# Altium schematic sheet [04] - USB-AND-GPIOS.SchDoc("[04] - USB-AND-GPIOS")
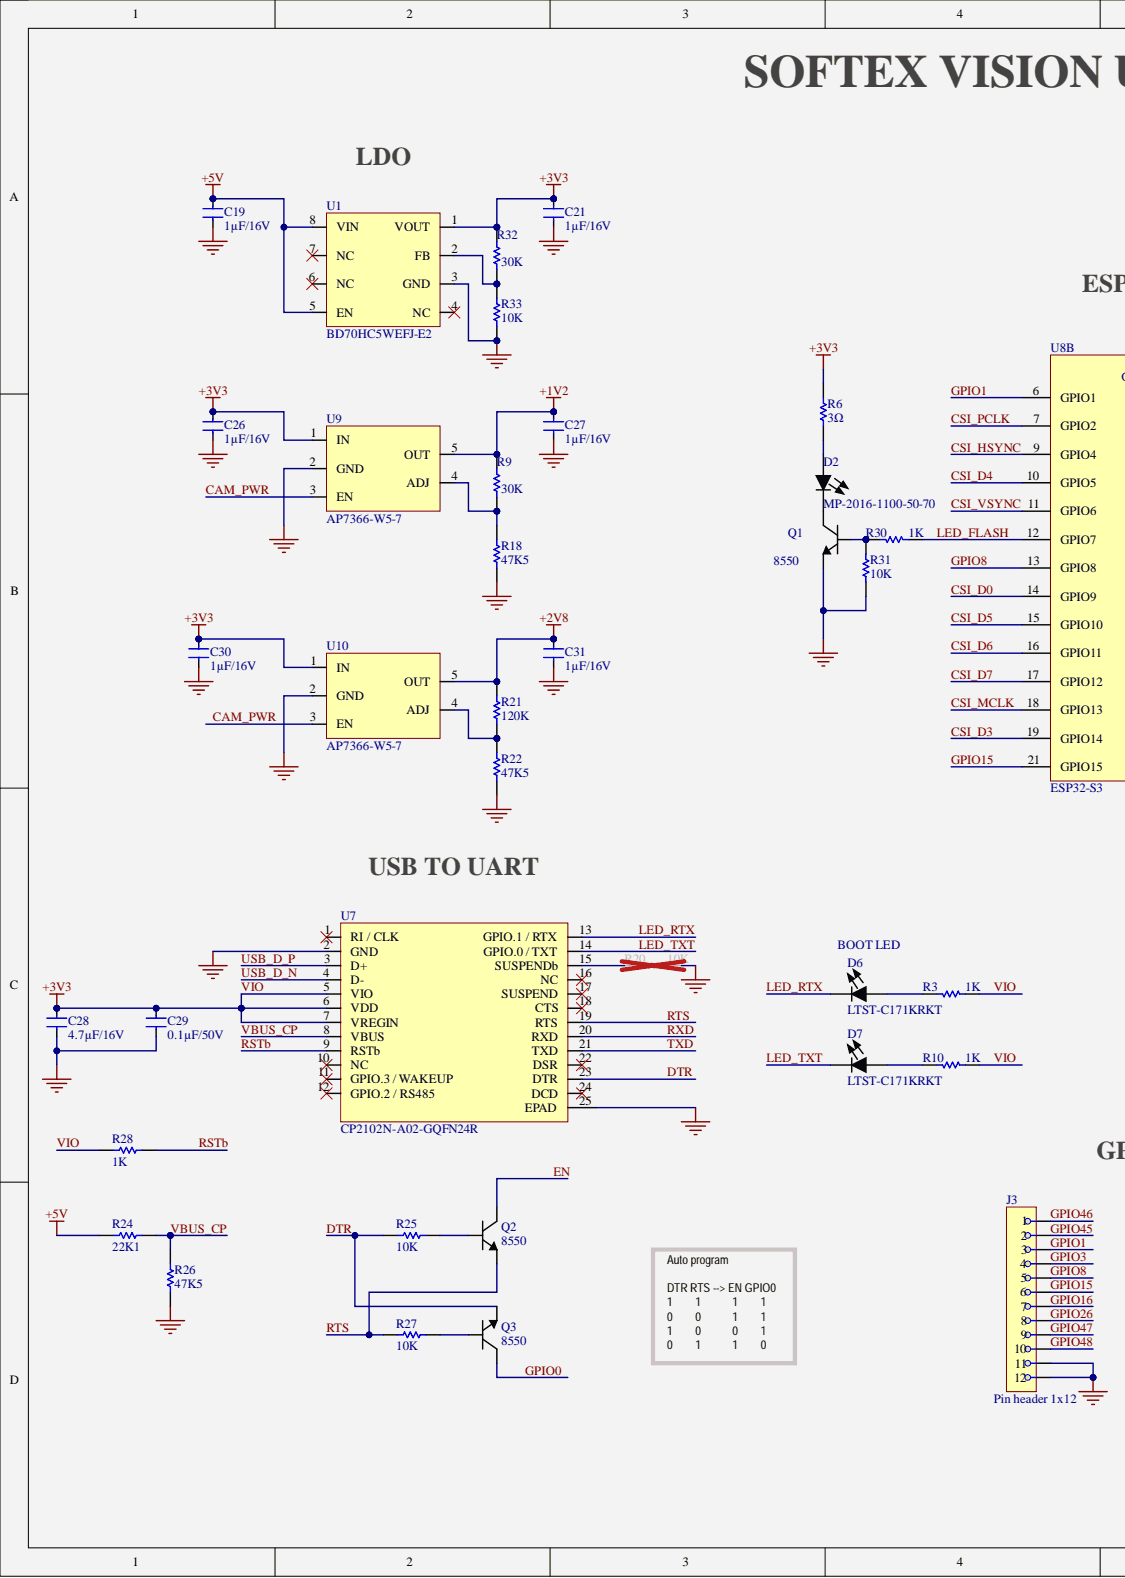
[diagram p0: [04] - USB-AND-GPIOS.SchDoc, left]
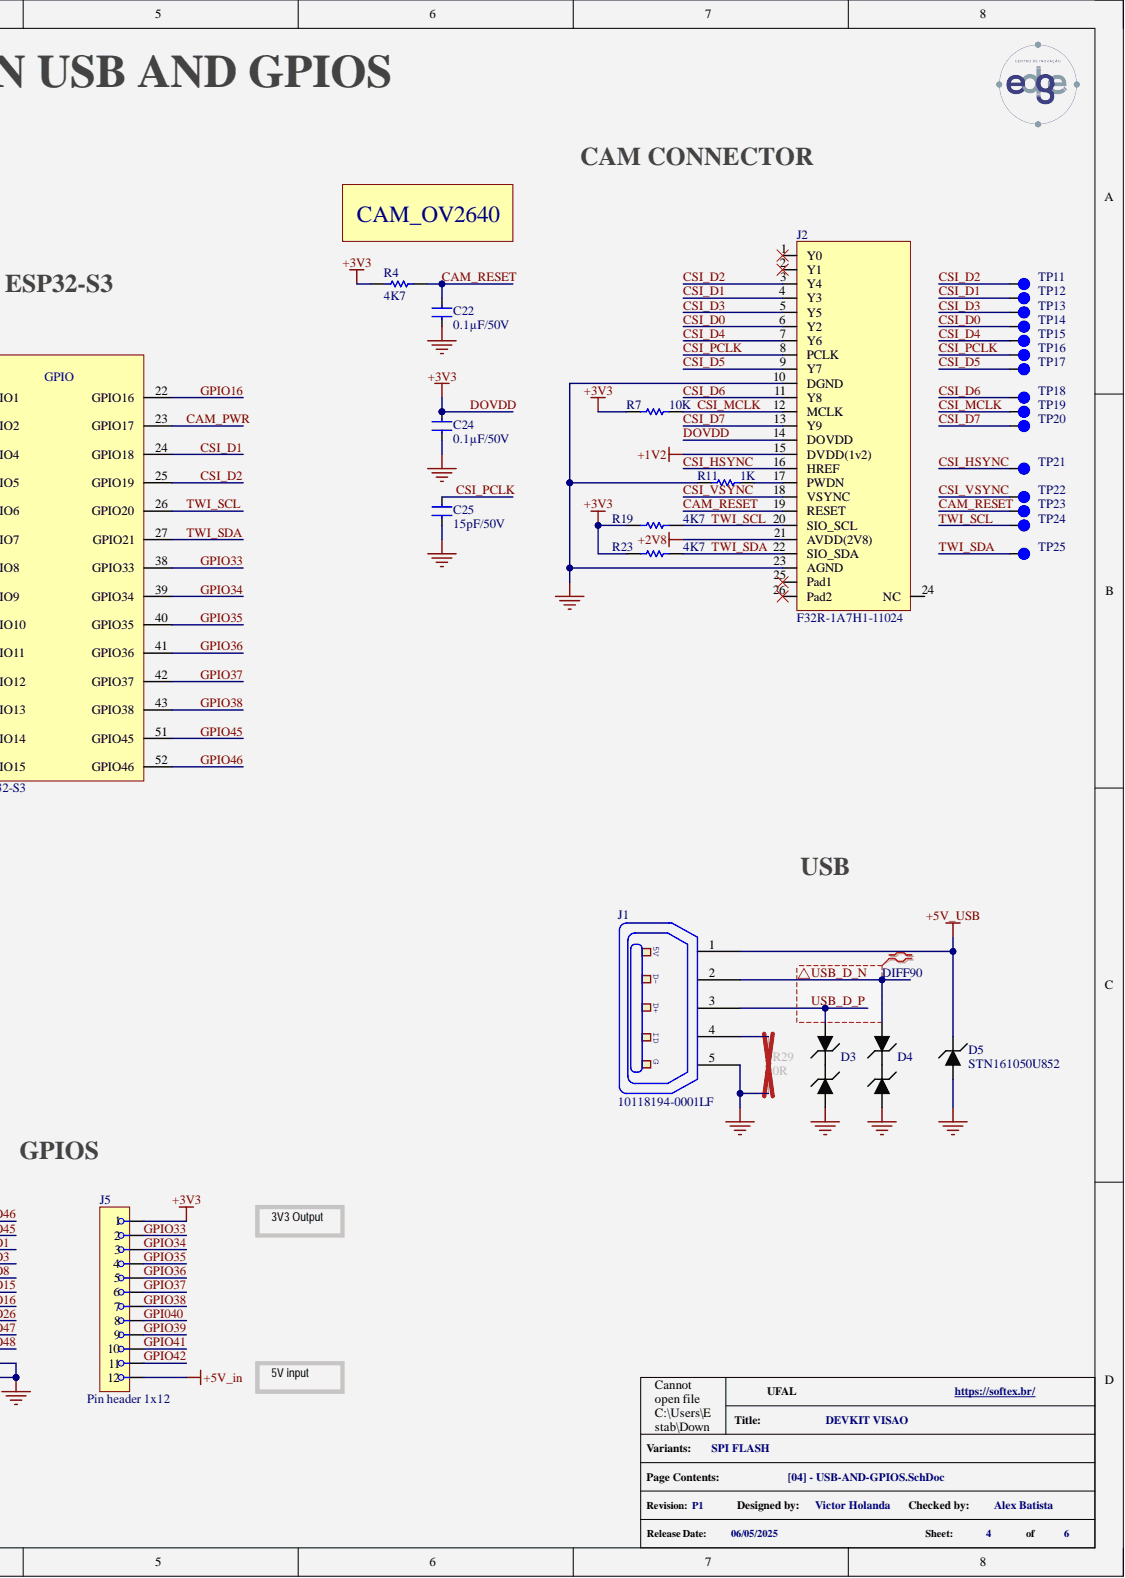
[diagram p1: [04] - USB-AND-GPIOS.SchDoc, right]

## Parts
*  CAM_OV2640  (mechanical)
C19  1µF/16V  [C0805]
C21  1µF/16V  [C0805]
C22  0.1µF/50V  [C0805]
C24  0.1µF/50V  [C0805]
C25  15pF/50V  [C0805]
C26  1µF/16V  [C0805]
C27  1µF/16V  [C0805]
C28  4.7µF/16V  [C0805]
C29  0.1µF/50V  [C0805]
C30  1µF/16V  [C0805]
C31  1µF/16V  [C0805]
D2  MP-2016-1100-50-70  [MP-2016-1100-50-70]
D3  SZESD7451N2T5G  [SZESD7451N2T5G]  (value not drawn)
D4  SZESD7451N2T5G  [SZESD7451N2T5G]  (value not drawn)
D5  STN161050U852  [STN161050U852]
D6  LTST-C171KRKT  [LTST-C171KRKT]
D7  LTST-C171KRKT  [LTST-C171KRKT]
J1  10118194-0001LF  [10118194-0001LF]
J2  F32R-1A7H1-11024  [F32R1A7H111024]
J3  Pin header 1x12  [PH1-12-UA]
J5  Pin header 1x12  [PH1-12-UA]
Q1  8550  [MMSS8050-H-TP]
Q2  8550  [MMSS8050-H-TP]
Q3  8550  [MMSS8050-H-TP]
R3  1K  [R0805]
R4  4K7  [R0805]
R6  3Ω  [R0805]
R7  10K  [R0805]
R9  30K  [R0805]
R10  1K  [R0805]
R11  1K  [R0805]
R18  47K5  [R0805]
R19  4K7  [R0805]
R20  10K  [R0805]
R21  120K  [R0805]
R22  47K5  [R0805]
R23  4K7  [R0805]
R24  22K1  [R0805]
R25  10K  [R0805]
R26  47K5  [R0805]
R27  10K  [R0805]
R28  1K  [R0805]
R29  0R  [R0805]
R30  1K  [R0805]
R31  10K  [R0805]
R32  30K  [R0805]
R33  10K  [R0805]
TP11  TestPoint  [Test Point]  (value not drawn)  (no BOM)
TP12  TestPoint  [Test Point]  (value not drawn)  (no BOM)
TP13  TestPoint  [Test Point]  (value not drawn)  (no BOM)
TP14  TestPoint  [Test Point]  (value not drawn)  (no BOM)
TP15  TestPoint  [Test Point]  (value not drawn)  (no BOM)
TP16  TestPoint  [Test Point]  (value not drawn)  (no BOM)
TP17  TestPoint  [Test Point]  (value not drawn)  (no BOM)
TP18  TestPoint  [Test Point]  (value not drawn)  (no BOM)
TP19  TestPoint  [Test Point]  (value not drawn)  (no BOM)
TP20  TestPoint  [Test Point]  (value not drawn)  (no BOM)
TP21  TestPoint  [Test Point]  (value not drawn)  (no BOM)
TP22  TestPoint  [Test Point]  (value not drawn)  (no BOM)
TP23  TestPoint  [Test Point]  (value not drawn)  (no BOM)
TP24  TestPoint  [Test Point]  (value not drawn)  (no BOM)
TP25  TestPoint  [Test Point]  (value not drawn)  (no BOM)
U1  BD70HC5WEFJ-E2  [BD70HC5WEFJ-E2]
U7  CP2102N-A02-GQFN24R  [CP2102N-A02-GQFN24]
U8  ESP32-S3  [ESP32-S3]  (part B)
U8
U9  AP7366-W5-7  [AP7366-W5-7]
U10  AP7366-W5-7  [AP7366-W5-7]

## Nets
+1V2: C27.2 J2.15(DVDD(1v2)) R9.2 U9.5(OUT)
+2V8: C31.2 J2.21(AVDD(2V8)) R21.2 U10.5(OUT)
+5V: C19.2 R24.2 U1.5(EN) U1.8(VIN)
+5V_in: J5.12
+5V_USB: D5.1 J1.1
GND: C19.1 C21.1 C22.2 C24.2 C25.1 C26.1 C27.1 C28.1 C29.1 C30.1 C31.1 D3.2 D4.2 D5.2 J1.5 J2.10(DGND) J2.23(AGND) J3.11 J3.12 Q1.2 R11.1 R18.1 R20.2 R22.1 R26.1 R29.1 R31.1 R33.1 U1.3(GND) U7.2(GND) U7.25(EPAD) U9.2(GND) U10.2(GND)
+3V3: C21.2 C24.1 C26.2 C28.2 C29.2 C30.2 J2.14(DOVDD) J5.1 R3.1 R4.1 R6.2 R7.1 R10.1 R19.1 R23.1 R28.1 R32.2 U1.1(VOUT) U7.5(VIO) U7.6(VDD) U7.7(VREGIN) U9.1(IN) U10.1(IN)
CAM_PWR: U8.23 U9.3(EN) U10.3(EN)
CAM_RESET: C22.1 J2.19(RESET) R4.2 TP23.1
CSI_D0: J2.6(Y2) TP14.1 U8.14
CSI_D1: J2.4(Y3) TP12.1 U8.24
CSI_D2: J2.3(Y4) TP11.1 U8.25
CSI_D3: J2.5(Y5) TP13.1 U8.19
CSI_D4: J2.7(Y6) TP15.1 U8.10
CSI_D5: J2.9(Y7) TP17.1 U8.15
CSI_D6: J2.11(Y8) TP18.1 U8.16
CSI_D7: J2.13(Y9) TP20.1 U8.17
CSI_HSYNC: J2.16(HREF) TP21.1 U8.9
CSI_MCLK: J2.12(MCLK) R7.2 TP19.1 U8.18
CSI_PCLK: C25.2 J2.8(PCLK) TP16.1 U8.7
CSI_VSYNC: J2.18(VSYNC) TP22.1 U8.11
DTR: Q3.2 R25.1 U7.23(DTR)
EN: Q2.3
GPI040: J5.8
GPIO0: Q3.3
GPIO1: J3.3 U8.6
GPIO3: J3.4
GPIO8: J3.5 U8.13
GPIO15: J3.6 U8.21
GPIO16: J3.7 U8.22
GPIO26: J3.8
GPIO33: J5.2 U8.38
GPIO34: J5.3 U8.39
GPIO35: J5.4 U8.40
GPIO36: J5.5 U8.41
GPIO37: J5.6 U8.42
GPIO38: J5.7 U8.43
GPIO39: J5.9
GPIO41: J5.10
GPIO42: J5.11
GPIO45: J3.2 U8.51
GPIO46: J3.1 U8.52
GPIO47: J3.9
GPIO48: J3.10
LED_FLASH: R30.2 U8.12
LED_RTX: D6.2 U7.13(GPIO.1_/_RTX)
LED_TXT: D7.2 U7.14(GPIO.0_/_TXT)
RSTb: R28.2 U7.9(RSTb)
RTS: Q2.2 R27.1 U7.19(RTS)
RXD: U7.20(RXD)
TWI_SCL: J2.20(SIO_SCL) R19.2 TP24.1 U8.26
TWI_SDA: J2.22(SIO_SDA) R23.2 TP25.1 U8.27
TXD: U7.21(TXD)
USB_D_N: D4.1 J1.2 U7.4(D-)
USB_D_P: D3.1 J1.3 U7.3(D+)
VBUS_CP: R24.1 R26.2 U7.8(VBUS)
NetD2_1: D2.1 R6.1
NetD2_2: D2.2 Q1.3
NetD6_1: D6.1 R3.2
NetD7_1: D7.1 R10.2
NetJ1_4: J1.4 R29.2
NetJ2_17: J2.17(PWDN) R11.2
NetQ1_1: Q1.1 R30.1 R31.2
NetQ2_1: Q2.1 R25.2
NetQ3_1: Q3.1 R27.2
NetR9_1: R9.1 R18.2 U9.4(ADJ)
NetR20_1: R20.1 U7.15(SUSPENDb)
NetR21_1: R21.1 R22.2 U10.4(ADJ)
NetR32_1: R32.1 R33.2 U1.2(FB)

Not connected: J2 1(Y0) 2(Y1) 24(NC) 25(Pad1) 26(Pad2); U1 4(NC) 6(NC) 7(NC); U7 1(RI_/_CLK) 10(NC) 11(GPIO.3_/_WAKEUP) 12(GPIO.2_/_RS485) 16(NC) 17(SUSPEND) 18(CTS) 22(DSR) 24(DCD)

## Library data of the parts
C19 C21 C26 C27 C30 C31 = CL21B105KOFNNNE: MLCC - 1µF 0805 16V X7R ±10%; Manufacturer 1: Samsung; .PCBChecked: Victor Holanda; Capacitance: 1uF; Case Code (Imperial): 0805; Case Code (Metric): 2012; Case/Package: 0805; Category: Ceramic Capacitors; Component Kind: Standard; ComponentLink3Description: https://datasheet.ciiva.com/25800/cl21b105kofnnne-25800257.pdf?src-supplier=Digi-Key; ComponentLink3URL: https://datasheet.ciiva.com/25800/cl21b105kofnnne-25800257.pdf?src-supplier=Digi-Key; Construction: Flat; Contact Plating: Tin; Depth: 1.25mm; Dielectric: X7R; Height: 1.25mm; Lead Free: Lead Free; Length: 2mm; Manufacturer Part Number 1: CL21B105KOFNNNE; Max Operating Temperature: 125°C; Min Operating Temperature: -55°C; Mount: Surface Mount; Package Quantity: 1; Packaging: Tape and Reel; Pricing 1: 1=0,10000, 10=0,05600, 50=0,03060, 100=0,02500, 500=0,01782, 1000=0,01401 (USD); Radiation Hardening: No; RoHS: Yes; RoHS Compliant: Yes; Series: CL; Stock 1: 1044699; Supplier 1: Digi-Key; Supplier Part Number 1: 1276-1026-1-ND; Thickness: 0.053inch; Tolerance: 10%; Voltage: 16V; Voltage Rating: 16V; Voltage Rating (DC): 16V; Width: 0.049inch; .SCHChecked: Victor Holanda; Library Name: Softex.SchLib
C22 C24 C29 = CL21B104KBCNNNC: MLCC - 0.1µF 0805 50V X7R ±10%; Manufacturer 1: Samsung; .PCBChecked: Victor Holanda; Capacitance: 100nF; Material: Ceramic; Case Code (Imperial): 0805; Case Code (Metric): 2012; Case/Package: 0805; Category: Ceramic Capacitors; Component Kind: Standard; ComponentLink3Description: https://datasheet.ciiva.com/25710/cl21b104kbcnnnc-25710182.pdf?src-supplier=Digi-Key; ComponentLink3URL: https://datasheet.ciiva.com/25710/cl21b104kbcnnnc-25710182.pdf?src-supplier=Digi-Key; Construction: Flat; Contact Plating: Tin; Depth: 1.25mm; Dielectric: X7R; Height: 0.85mm; Lead Free: Lead Free; Length: 2mm; Manufacturer Part Number 1: CL21B104KBCNNNC; Max Operating Temperature: 125°C; Min Operating Temperature: -55°C; Mount: Surface Mount; Packaging: Tape and Reel; Pricing 1: 1=0,10000, 10=0,04800, 50=0,02620, 100=0,02140, 500=0,01528, 1000=0,01201 (USD); Radiation Hardening: No; RoHS: Yes; RoHS Compliant: Yes; Series: CL; Stock 1: 3198898; Supplier 1: Digi-Key; Supplier 2: Mouser; Supplier Part Number 1: 1276-1003-1-ND; Supplier Part Number 2: 187-CL21B104KBCNNNC; Thickness: 0.037inch; Tolerance: 10%; Voltage: 50V; Voltage Rating: 50V; Voltage Rating (DC): 50V; Width: 0.049inch; .SCHChecked: Victor Holanda; Library Name: Softex.SchLib
C25 = 08055C150KAT2A: MLCC - 15pF 0805 50V X7R ±10%; Manufacturer 1: Kyocera AVX; .PCBChecked: Victor Holanda; Capacitance: 15pF; Case Code (Imperial): 0805; Case Code (Metric): 2012; Case/Package: 0805; Category: Ceramic Capacitors; Component Kind: Standard; Construction: Flat; Depth: 1.25mm; Dielectric: X7R; Length: 2.01mm; Manufacturer Part Number 1: 08055C150KAT2A; Max Operating Temperature: 125°C; Min Operating Temperature: -55°C; Mount: Surface Mount; Number of Pins: 2; Package Quantity: 4000; Packaging: Tape and Reel; Pricing 1: 1=0,23000, 10=0,15700, 50=0,09400, 100=0,07940, 500=0,05434, 1000=0,04807 (USD); Radiation Hardening: No; REACH SVHC: Unknown; RoHS: Yes; Stock 1: 16775; Supplier 1: Digi-Key; Supplier 2: Mouser; Supplier Part Number 1: 478-11902-1-ND; Supplier Part Number 2: 581-08055C150KAT2A; Termination: SMD/SMT; Thickness: 0.037inch; Tolerance: 10%; Voltage Rating: 50V; Voltage Rating (DC): 50V; Width: 0.049inch; .SCHChecked: Victor Holanda; Library Name: Softex.SchLib
C28 = CL21A475KOFNNNE: MLCC - 4.7µF 0805 16V X5R ±10%; Manufacturer 1: Samsung; .PCBChecked: Victor Holanda; Capacitance: 4.7uF; Case Code (Imperial): 0805; Case Code (Metric): 2012; Case/Package: 0805; Category: Ceramic Capacitors; Component Kind: Standard; ComponentLink3Description: https://datasheet.ciiva.com/25710/cl21a475kofnnne-25710354.pdf?src-supplier=Digi-Key; ComponentLink3URL: https://datasheet.ciiva.com/25710/cl21a475kofnnne-25710354.pdf?src-supplier=Digi-Key; ComponentLink4Description: https://datasheet.ciiva.com/16740/cl21a475kofnnne-16740646.pdf?src-supplier=Future+Electronics; ComponentLink4URL: https://datasheet.ciiva.com/16740/cl21a475kofnnne-16740646.pdf?src-supplier=Future+Electronics; ComponentLink5Description: https://datasheet.ciiva.com/26838/getdatasheetpartid-357424-26838625.pdf?src-supplier=Verical; ComponentLink5URL: https://datasheet.ciiva.com/26838/getdatasheetpartid-357424-26838625.pdf?src-supplier=Verical; Construction: Flat; Contact Plating: Tin; Depth: 1.25mm; Dielectric: X5R; Height: 1.25mm; Length: 2mm; Manufacturer Part Number 1: CL21A475KOFNNNE; Max Operating Temperature: 85°C; Min Operating Temperature: -55°C; Mount: Surface Mount; Packaging: Tape and Reel; Pricing 1: 1=0,12000, 10=0,08600, 50=0,04880, 100=0,04050, 500=0,02838, 1000=0,02350 (USD); Radiation Hardening: No; RoHS: Yes; RoHS Compliant: Yes; Series: CL; Stock 1: 605133; Supplier 1: Digi-Key; Supplier Part Number 1: 1276-1065-1-ND; Thickness: 0.053inch; Tolerance: 10%; Voltage Rating: 16V; Width: 0.049inch; .SCHChecked: Victor Holanda; Library Name: Softex.SchLib
D2 = MP-2016-1100-50-70: LED - Uni-Color White 27lm 5000K Chip LED 2-Pin SMD T/R; Manufacturer 1: Luminus Devices; .PCBChecked: Victor H; Color Rendering Index (CRI): 70; Forward Voltage: 3V; Lens Style: Rectangular; Max Current Rating: 120mA; Max Power Dissipation: 816mW; Number of LEDs: 1; Reverse Voltage: 5V; Test Current: 60mA; Thermal Resistance of Package: 35°C/W; Viewing Angle: 110°; Case Code (Imperial): 0806; Case Code (Metric): 2016; Case/Package: 0806; Category: Uncategorized; Color: White; Component Kind: Standard; ComponentLink1Description: https://datasheet.ciiva.com/48679/luminus-mp2016-datasheet-48679211.pdf?src-supplier=Avnet; ComponentLink1URL: https://datasheet.ciiva.com/48679/luminus-mp2016-datasheet-48679211.pdf?src-supplier=Avnet; Correlated Color Temperature (CCT): 5000 K; Luminous Flux: 27lm; Manufacturer Part Number 1: MP-2016-1100-50-70; Max Operating Temperature: 80°C; Min Operating Temperature: -40°C; Mount: Surface Mount; Number of Pins: 2; Packaging: Tape and Reel; Pricing 1: 1=0,16000, 10=0,08600, 100=0,04060, 500=0,03476, 1000=0,02674, 2000=0,02514 (USD); RoHS: Yes; RoHS Compliant: Yes; Stock 1: 60114; Supplier 1: Digi-Key; Supplier Part Number 1: 1214-1209-1-ND; .SCHChecked: Victor H; Library Name: softex-devkit-visao.SchLib
D3 D4 = SZESD7451N2T5G: Transient Voltage Suppressors low cap ESD protection in SOD-882; Manufacturer 1: ON Semiconductor; .PCBChecked: Victor H; Capacitance: 0.35pF; Clamping Voltage: 13V; Direction: Bidirectional; Element Configuration: Single; Min Breakdown Voltage: 6V; Number of Bidirectional Channels: 1; Power Line Protection: No; Reverse Standoff Voltage: 3.3V; Category: TVS Diodes; Component Kind: Standard; ComponentLink1Description: https://datasheet.ciiva.com/48533/esd7451-d-48533317.pdf?src-supplier=Avnet; ComponentLink1URL: https://datasheet.ciiva.com/48533/esd7451-d-48533317.pdf?src-supplier=Avnet; Lead Free: Lead Free; Manufacturer Part Number 1: SZESD7451N2T5G; Max Operating Temperature: 150°C; Min Operating Temperature: -55°C; Mount: Surface Mount; Number of Pins: 2; Packaging: Tape and Reel; Pricing 1: 1=0,49000, 10=0,42100, 100=0,31430, 500=0,24696, 1000=0,19083, 2000=0,17400 (USD); Resistor Type: Zener; RoHS: Yes; RoHS Compliant: Yes; Stock 1: 36434; Supplier 1: Digi-Key; Supplier Part Number 1: SZESD7451N2T5GOSCT-ND; Working Voltage: 3.3V; .SCHChecked: Victor H; Library Name: softex-devkit-visao.SchLib
D5 = STN161050U852: DIODE - ESD Suppressor TVS Single Uni-Dir 30KV 2-Pin SMD; Manufacturer 1: Eaton Bussmann; .PCBChecked: Victor H; Component Kind: Standard; Manufacturer Part Number 1: STN161050U852; Pricing 1: 1=0,50000, 10=0,37600, 100=0,23410, 500=0,16016, 1000=0,12320 (USD); RoHS: No; Stock 1: 8226; Supplier 1: Digi-Key; Supplier Part Number 1: 283-STN161050U852CT-ND; .SCHChecked: Victor H; Library Name: softex-devkit-visao.SchLib
D6 D7 = LTST-C171KRKT: LED - RED 2-Pin SMD 0805; Manufacturer 1: Vishay Lite-On; .PCBChecked: Jonatha O.; Dominant Wavelength: 631nm; Forward Current: 30mA; Forward Voltage: 2V; Lens Color: Colorless; Lens Style: Diffused,Colorless,Rectangular,Clear; Lens Transparency: Clear; Luminous Intensity: 54mcd; Number of Elements: 1; Power Dissipation: 75mW; Reverse Voltage: 5V; Test Current: 20mA; Viewing Angle: 130°; Wavelength: 639nm; Case Code (Imperial): 0805; Case Code (Metric): 2012; Case/Package: 0805; Color: Red; Component Kind: Standard; ComponentLink1Description: https://datasheet.ciiva.com/12403/lite-on-ltst-c171krkt-187201-12403781.pdf?src-supplier=Mouser; ComponentLink1URL: https://datasheet.ciiva.com/12403/lite-on-ltst-c171krkt-187201-12403781.pdf?src-supplier=Mouser; ComponentLink2Description: https://datasheet.ciiva.com/11775/0900766b80dc0299-11775643.pdf?src-supplier=RSComponents; ComponentLink2URL: https://datasheet.ciiva.com/11775/0900766b80dc0299-11775643.pdf?src-supplier=RSComponents; ComponentLink3Description: https://datasheet.ciiva.com/16444/lite-on20ltst-c171krkt-16444779.pdf?src-supplier=Future+Electronics; ComponentLink3URL: https://datasheet.ciiva.com/16444/lite-on20ltst-c171krkt-16444779.pdf?src-supplier=Future+Electronics; ComponentLink4Description: https://datasheet.ciiva.com/2110/s-110-ltst-c171krkt-2110066.pdf?src-supplier=Digi-Key; ComponentLink4URL: https://datasheet.ciiva.com/2110/s-110-ltst-c171krkt-2110066.pdf?src-supplier=Digi-Key; ComponentLink5Description: https://datasheet.ciiva.com/2110/s-110-ltst-c171krkt-2110067.pdf?src-supplier=Digi-Key; ComponentLink5URL: https://datasheet.ciiva.com/2110/s-110-ltst-c171krkt-2110067.pdf?src-supplier=Digi-Key; ComponentLink6Description: https://datasheet.ciiva.com/2110/s-110-ltst-c171krkt-2110068.pdf?src-supplier=Digi-Key; ComponentLink6URL: https://datasheet.ciiva.com/2110/s-110-ltst-c171krkt-2110068.pdf?src-supplier=Digi-Key; Depth: 1.25mm; Height: 0.8mm; Illumination Color: Red; Lead Free: Lead Free; Length: 2mm; Manufacturer Part Number 1: LTST-C171KRKT; Max Operating Temperature: 85°C; Min Operating Temperature: -55°C; Mount: Surface Mount; Number of LEDs: 1; Number of Pins: 2; Package Quantity: 3000; Packaging: Tape and Reel; Power Rating: 75mW; Pricing 1: 1=0.28000, 10=0.15000, 100=0.06990, 500=0.06450, 1000=0.05052 (USD); Radiation Hardening: No; RoHS: Yes; RoHS Compliant: Yes; Series: -; Stock 1: 300217; Supplier 1: Digi-Key; Supplier Part Number 1: 160-1427-1-ND; Width: 1.25mm; .SCHChecked: Jonatha O.; Library Name: softex-devkit-visao.SchLib
J1 = 10118194-0001LF: CONN - Micro USB Type B RCP 5 POS 0.65mm Solder RA SMD 5 Terminal 1 Port T/R; Manufacturer 1: Amphenol ICC; .PCBChecked: Jose Gomes; .SCHChecked: Victor H; Category: USB Connectors; Component Kind: Standard; ComponentLink1Description: http://components.arrow.com/part/dataview/0323_04107371?src-supplier=Arrow+Europe; ComponentLink1URL: http://components.arrow.com/part/dataview/0323_04107371?src-supplier=Arrow+Europe; ComponentLink2Description: http://uk.rs-online.com/webdocs/1450/0900766b814507ee.pdf?src-supplier=RSComponents; ComponentLink2URL: http://uk.rs-online.com/webdocs/1450/0900766b814507ee.pdf?src-supplier=RSComponents; ComponentLink3Description: https://cdn.amphenol-icc.com/media/wysiwyg/files/documentation/datasheet/inputoutput/io_usb_micro.pdf?src-supplier=Avnet; ComponentLink3URL: https://cdn.amphenol-icc.com/media/wysiwyg/files/documentation/datasheet/inputoutput/io_usb_micro.pdf?src-supplier=Avnet; ComponentLink4Description: https://cdn.amphenol-icc.com/media/wysiwyg/files/documentation/datasheet/inputoutput/io_usb_micro.pdf?src-supplier=Arrow+Electronics; ComponentLink4URL: https://cdn.amphenol-icc.com/media/wysiwyg/files/documentation/datasheet/inputoutput/io_usb_micro.pdf?src-supplier=Arrow+Electronics; ComponentLink5Description: https://cdn.amphenol-icc.com/media/wysiwyg/files/documentation/datasheet/inputoutput/io_usb_micro.pdf?src-supplier=Verical; ComponentLink5URL: https://cdn.amphenol-icc.com/media/wysiwyg/files/documentation/datasheet/inputoutput/io_usb_micro.pdf?src-supplier=Verical; ComponentLink6Description: http://portal.fciconnect.com/Comergent//fci/drawing/10118192.pdf?src-supplier=Avnet+Asia; ComponentLink6URL: http://portal.fciconnect.com/Comergent//fci/drawing/10118192.pdf?src-supplier=Avnet+Asia; ComponentLink7Description: https://cdn.amphenol-icc.com/media/wysiwyg/files/documentation/datasheet/inputoutput/io_usb_micro.pdf?src-supplier=Allied+Electronics; ComponentLink7URL: https://cdn.amphenol-icc.com/media/wysiwyg/files/documentation/datasheet/inputoutput/io_usb_micro.pdf?src-supplier=Allied+Electronics; ComponentLink8Description: http://www.farnell.com/datasheets/2366786.pdf?src-supplier=Newark; ComponentLink8URL: http://www.farnell.com/datasheets/2366786.pdf?src-supplier=Newark; ComponentLink9Description: https://cdn.amphenol-icc.com/media/wysiwyg/files/documentation/datasheet/inputoutput/io_usb_micro.pdf?src-supplier=Mouser; ComponentLink9URL: https://cdn.amphenol-icc.com/media/wysiwyg/files/documentation/datasheet/inputoutput/io_usb_micro.pdf?src-supplier=Mouser; ComponentLink10Description: http://www.amphenol-icc.com/media/wysiwyg/files/drawing/10118192.pdf?src-supplier=Digi-Key; ComponentLink10URL: http://www.amphenol-icc.com/media/wysiwyg/files/drawing/10118192.pdf?src-supplier=Digi-Key; ComponentLink11Description: http://www.farnell.com/cad/1935767.pdf?src-supplier=Element14; ComponentLink11URL: http://www.farnell.com/cad/1935767.pdf?src-supplier=Element14; ComponentLink12Description: http://www.farnell.com/datasheets/2366786.pdf?src-supplier=Farnell; ComponentLink12URL: http://www.farnell.com/datasheets/2366786.pdf?src-supplier=Farnell; ComponentLink13Description: https://cdn.amphenol-icc.com/media/wysiwyg/files/documentation/datasheet/inputoutput/io_usb_micro.pdf?src-supplier=TME; ComponentLink13URL: https://cdn.amphenol-icc.com/media/wysiwyg/files/documentation/datasheet/inputoutput/io_usb_micro.pdf?src-supplier=TME; ComponentLink14Description: https://cdn.amphenol-icc.com/media/wysiwyg/files/documentation/datasheet/inputoutput/io_usb_micro.pdf?src-supplier=TTI; ComponentLink14URL: https://cdn.amphenol-icc.com/media/wysiwyg/files/documentation/datasheet/inputoutput/io_usb_micro.pdf?src-supplier=TTI; ComponentLink15Description: https://cdn.amphenol-icc.com/media/wysiwyg/files/documentation/datasheet/inputoutput/io_usb_micro.pdf?src-supplier=NAC+Semi; ComponentLink15URL: https://cdn.amphenol-icc.com/media/wysiwyg/files/documentation/datasheet/inputoutput/io_usb_micro.pdf?src-supplier=NAC+Semi; ComponentLink16Description: https://cdn.amphenol-icc.com/media/wysiwyg/files/documentation/datasheet/inputoutput/io_usb_micro.pdf?src-supplier=SOS+electronic; ComponentLink16URL: https://cdn.amphenol-icc.com/media/wysiwyg/files/documentation/datasheet/inputoutput/io_usb_micro.pdf?src-supplier=SOS+electronic; ComponentLink17Description: https://cdn.amphenol-icc.com/media/wysiwyg/files/documentation/datasheet/inputoutput/io_usb_micro.pdf?src-supplier=Freelance+Electronics; ComponentLink17URL: https://cdn.amphenol-icc.com/media/wysiwyg/files/documentation/datasheet/inputoutput/io_usb_micro.pdf?src-supplier=Freelance+Electronics; ComponentLink18Description: https://cdn.amphenol-icc.com/media/wysiwyg/files/documentation/datasheet/inputoutput/io_usb_micro.pdf?src-supplier=Heilind+Electronics; ComponentLink18URL: https://cdn.amphenol-icc.com/media/wysiwyg/files/documentation/datasheet/inputoutput/io_usb_micro.pdf?src-supplier=Heilind+Electronics; ComponentLink19Description: https://datasheet.ciiva.com/3094/1050170001-io-connectors-3094416.pdf?src-supplier=Avnet; ComponentLink19URL: https://datasheet.ciiva.com/3094/1050170001-io-connectors-3094416.pdf?src-supplier=Avnet; ComponentLink20Description: https://datasheet.ciiva.com/30165/235271050170001-io-nectors-30165183.pdf?src-supplier=Onlinecomponents.com; ComponentLink20URL: https://datasheet.ciiva.com/30165/235271050170001-io-nectors-30165183.pdf?src-supplier=Onlinecomponents.com; ComponentLink21Description: https://datasheet.ciiva.com/5742/1598502-5742508.pdf?src-supplier=Farnell; ComponentLink21URL: https://datasheet.ciiva.com/5742/1598502-5742508.pdf?src-supplier=Farnell; ComponentLink22Description: https://datasheet.ciiva.com/8015/1631959-8015288.pdf?src-supplier=Element14; ComponentLink22URL: https://datasheet.ciiva.com/8015/1631959-8015288.pdf?src-supplier=Element14; ComponentLink23Description: https://datasheet.ciiva.com/22654/1688140-22654924.pdf?src-supplier=Element14; ComponentLink23URL: https://datasheet.ciiva.com/22654/1688140-22654924.pdf?src-supplier=Element14; ComponentLink24Description: https://datasheet.ciiva.com/4598/1050170001-io-connectors-152207-4598759.pdf?src-supplier=Mouser; ComponentLink24URL: https://datasheet.ciiva.com/4598/1050170001-io-connectors-152207-4598759.pdf?src-supplier=Mouser; ComponentLink25Description: https://datasheet.ciiva.com/851/1050170001-sd-851276.pdf?src-supplier=Digi-Key; ComponentLink25URL: https://datasheet.ciiva.com/851/1050170001-sd-851276.pdf?src-supplier=Digi-Key; ComponentLink26Description: https://datasheet.ciiva.com/16668/1050170001-io-connectors-16668598.pdf?src-supplier=Avnet+Europe; ComponentLink26URL: https://datasheet.ciiva.com/16668/1050170001-io-connectors-16668598.pdf?src-supplier=Avnet+Europe; ComponentLink27Description: https://datasheet.ciiva.com/851/1050170001-sd-851277.pdf?src-supplier=Digi-Key; ComponentLink27URL: https://datasheet.ciiva.com/851/1050170001-sd-851277.pdf?src-supplier=Digi-Key; ComponentLink28Description: https://datasheet.ciiva.com/851/1050170001-sd-851278.pdf?src-supplier=Digi-Key; ComponentLink28URL: https://datasheet.ciiva.com/851/1050170001-sd-851278.pdf?src-supplier=Digi-Key; ComponentLink29Description: http://components.arrow.com/part/dataview/0323_04107373?src-supplier=Arrow+Europe; ComponentLink29URL: http://components.arrow.com/part/dataview/0323_04107373?src-supplier=Arrow+Europe; ComponentLink30Description: https://cdn.amphenol-icc.com/media/wysiwyg/files/drawing/10118194.pdf?src-supplier=Avnet; ComponentLink30URL: https://cdn.amphenol-icc.com/media/wysiwyg/files/drawing/10118194.pdf?src-supplier=Avnet; ComponentLink31Description: https://cdn.amphenol-icc.com/media/wysiwyg/files/drawing/10118194.pdf?src-supplier=Mouser; ComponentLink31URL: https://cdn.amphenol-icc.com/media/wysiwyg/files/drawing/10118194.pdf?src-supplier=Mouser; ComponentLink32Description: http://www.amphenol-icc.com/media/wysiwyg/files/drawing/10118194.pdf?src-supplier=Digi-Key; ComponentLink32URL: http://www.amphenol-icc.com/media/wysiwyg/files/drawing/10118194.pdf?src-supplier=Digi-Key; ComponentLink33Description: http://www.farnell.com/cad/2354948.pdf?src-supplier=Farnell; ComponentLink33URL: http://www.farnell.com/cad/2354948.pdf?src-supplier=Farnell; ComponentLink34Description: https://cdn.amphenol-icc.com/media/wysiwyg/files/drawing/10118194.pdf?src-supplier=RSComponents; ComponentLink34URL: https://cdn.amphenol-icc.com/media/wysiwyg/files/drawing/10118194.pdf?src-supplier=RSComponents; ComponentLink35Description: https://cdn.amphenol-icc.com/media/wysiwyg/files/drawing/10118194.pdf?src-supplier=Allied+Electronics; ComponentLink35URL: https://cdn.amphenol-icc.com/media/wysiwyg/files/drawing/10118194.pdf?src-supplier=Allied+Electronics; ComponentLink36Description: https://cdn.amphenol-icc.com/media/wysiwyg/files/drawing/10118194.pdf?src-supplier=Verical; ComponentLink36URL: https://cdn.amphenol-icc.com/media/wysiwyg/files/drawing/10118194.pdf?src-supplier=Verical; ComponentLink37Description: https://cdn.amphenol-icc.com/media/wysiwyg/files/drawing/10118194.pdf?src-supplier=Arrow+Electronics; ComponentLink37URL: https://cdn.amphenol-icc.com/media/wysiwyg/files/drawing/10118194.pdf?src-supplier=Arrow+Electronics; ComponentLink38Description: https://cdn.amphenol-icc.com/media/wysiwyg/files/drawing/10118194.pdf?src-supplier=TTI; ComponentLink38URL: https://cdn.amphenol-icc.com/media/wysiwyg/files/drawing/10118194.pdf?src-supplier=TTI; ComponentLink39Description: https://cdn.amphenol-icc.com/media/wysiwyg/files/drawing/10118194.pdf?src-supplier=NAC+Semi; ComponentLink39URL: https://cdn.amphenol-icc.com/media/wysiwyg/files/drawing/10118194.pdf?src-supplier=NAC+Semi; ComponentLink40Description: https://cdn.amphenol-icc.com/media/wysiwyg/files/drawing/10118194.pdf?src-supplier=SOS+electronic; ComponentLink40URL: https://cdn.amphenol-icc.com/media/wysiwyg/files/drawing/10118194.pdf?src-supplier=SOS+electronic; ComponentLink41Description: https://cdn.amphenol-icc.com/media/wysiwyg/files/drawing/10118194.pdf?src-supplier=Quest; ComponentLink41URL: https://cdn.amphenol-icc.com/media/wysiwyg/files/drawing/10118194.pdf?src-supplier=Quest; ComponentLink42Description: https://cdn.amphenol-icc.com/media/wysiwyg/files/drawing/10118194.pdf?src-supplier=Heilind+Electronics; ComponentLink42URL: https://cdn.amphenol-icc.com/media/wysiwyg/files/drawing/10118194.pdf?src-supplier=Heilind+Electronics; ComponentLink43Description: https://cdn.amphenol-icc.com/media/wysiwyg/files/drawing/10118194.pdf?src-supplier=TME; ComponentLink43URL: https://cdn.amphenol-icc.com/media/wysiwyg/files/drawing/10118194.pdf?src-supplier=TME; ComponentLink44Description: http://www.farnell.com/cad/2354948.pdf?src-supplier=Element14; ComponentLink44URL: http://www.farnell.com/cad/2354948.pdf?src-supplier=Element14; Manufacturer Part Number 1: 10118194-0001LF; Pricing 1: 1=0,480000, 10=0,420000, 25=0,384400, 50=0,361600, 100=0,346600, 250=0,316400, 500=0,301360 (USD); RoHS: Yes; RoHS Compliant: Yes; Stock 1: 169666; Supplier 1: Digi-Key; Supplier 2: Mouser; Supplier Part Number 1: 609-4618-1-ND; Supplier Part Number 2: 649-10118194-0001LF; Library Name: softex-devkit-visao.SchLib
J2 = F32R-1A7H1-11024: CONN - FPC/FFC Connector Socket 24 Position 0.5mm Bottom Contact Solder Right Angle Surface Mount T/R; Manufacturer 1: Amphenol ICC / FCI; .PCBChecked: Victor H; Category: FFC / FPC; Component Kind: Standard; Manufacturer Part Number 1: F32R-1A7H1-11024; Pricing 1: 1=0,82000, 10=0,64500, 50=0,64500, 100=0,56100, 500=0,50500, 1000=0,38300, 2500=0,36400, 10000=0,36400 (USD); RoHS: No; Stock 1: 588; Supplier 1: Mouser; Supplier Part Number 1: 649-F32R-1A7H1-11024; .SCHChecked: Victor H; Library Name: softex-devkit-visao.SchLib
J3 J5 = PH1-12-UA: CONN - HEADER VERT 12POS 2.54MM; Manufacturer 1: Adam Equipment; .PCBChecked: Victor H; Category: Headers and Wire Housings; Component Kind: Standard; ComponentLink1Description: http://www.adam-tech.com/catalog/2012/page_312-315.pdf?src-supplier=Future+Electronics; ComponentLink1URL: http://www.adam-tech.com/catalog/2012/page_312-315.pdf?src-supplier=Future+Electronics; ComponentLink2Description: https://datasheet.ciiva.com/16480/page-312-315-16480233.pdf?src-supplier=Future+Electronics; ComponentLink2URL: https://datasheet.ciiva.com/16480/page-312-315-16480233.pdf?src-supplier=Future+Electronics; Lead Free: Lead Free; Manufacturer Part Number 1: PH1-12-UA; Pricing 1: 1=0,21000, 10=0,17600, 100=0,13510, 500=0,11434, 1000=0,09356, 5000=0,08316, 10000=0,07536 (USD); RoHS: Yes; Stock 1: 4680; Supplier 1: Digi-Key; Supplier Part Number 1: 2057-PH1-12-UA-ND; .SCHChecked: Victor H; Library Name: softex-devkit-visao.SchLib
Q1-Q3 = MMSS8050-H-TP: TRANSISTOR - NPN 25V 1.5A SOT23; Manufacturer 1: MCC; .PCBChecked: Victor H; Collector Base Voltage (VCBO): 40V; Collector Emitter Saturation Voltage: 500mV; Element Configuration: Single; Emitter Base Voltage (VEBO): 5V; Gain Bandwidth Product: 100MHz; hFE Min: 120; Max Power Dissipation: 625mW; mouting: SMD; Case/Package: SOT-23; Category: BJTs; Collector Emitter Breakdown Voltage: 25V; Collector Emitter Voltage (VCEO): 500mV; Collector-emitter Voltage-Max: 500mV; Component Kind: Standard; ComponentLink1Description: https://datasheet.ciiva.com/18648/dss5320t-18648043.pdf?src-supplier=Digi-Key; ComponentLink1URL: https://datasheet.ciiva.com/18648/dss5320t-18648043.pdf?src-supplier=Digi-Key; ComponentLink2Description: https://datasheet.ciiva.com/11206/dss5320t-11206349.pdf?src-supplier=Avnet; ComponentLink2URL: https://datasheet.ciiva.com/11206/dss5320t-11206349.pdf?src-supplier=Avnet; ComponentLink3Description: https://datasheet.ciiva.com/16291/mmss8050-255048-16291447.pdf?src-supplier=Mouser; ComponentLink3URL: https://datasheet.ciiva.com/16291/mmss8050-255048-16291447.pdf?src-supplier=Mouser; ComponentLink4Description: https://datasheet.ciiva.com/972/mmss8050sot-23-972894.pdf?src-supplier=Digi-Key; ComponentLink4URL: https://datasheet.ciiva.com/972/mmss8050sot-23-972894.pdf?src-supplier=Digi-Key; ComponentLink5Description: https://datasheet.ciiva.com/972/mmss8050sot-23-972895.pdf?src-supplier=Digi-Key; ComponentLink5URL: https://datasheet.ciiva.com/972/mmss8050sot-23-972895.pdf?src-supplier=Digi-Key; ComponentLink6Description: https://datasheet.ciiva.com/972/mmss8050sot-23-972893.pdf?src-supplier=Digi-Key; ComponentLink6URL: https://datasheet.ciiva.com/972/mmss8050sot-23-972893.pdf?src-supplier=Digi-Key; Lead Free: Lead Free; Manufacturer Part Number 1: MMSS8050-H-TP; Max Breakdown Voltage: 25V; Max Collector Current: 1.5A; Max Operating Temperature: 150°C; Min Operating Temperature: -55°C; Mount: Surface Mount; Number of Pins: 3; Package Quantity: 3000; Packaging: Tape and Reel; Polarity: NPN; Pricing 1: 1=0,21000, 10=0,17100, 100=0,09060, 500=0,05962, 1000=0,04054 (USD); RoHS: Yes; RoHS Compliant: Yes; Series: -; Stock 1: 87310; Supplier 1: Digi-Key; Supplier Part Number 1: MMSS8050-H-TPMSCT-ND; Transition Frequency: 100MHz; .SCHChecked: Victor H; Library Name: softex-devkit-visao.SchLib
R3 R10 R11 R28 R30 = RNCP0805FTD1K00: RES - 1K 1/4W 0805 ±1%; Manufacturer 1: Stackpole Electronics; .PCBChecked: Victor Holanda; Max Power Dissipation: 250mW; Resistance: 1kR; Temperature Coefficient: 100ppm/°C; Case Code (Imperial): 0805; Case Code (Metric): 2012; Case/Package: 0805; Category: Chip SMD Resistors; Component Kind: Standard; ComponentLink7Description: http://www.seielect.com/Catalog/SEI-rncp.pdf?src-supplier=Future+Electronics; ComponentLink7URL: http://www.seielect.com/Catalog/SEI-rncp.pdf?src-supplier=Future+Electronics; ComponentLink8Description: https://www.seielect.com/Catalog/SEI-rncp.pdf?src-supplier=Digi-Key; ComponentLink8URL: https://www.seielect.com/Catalog/SEI-rncp.pdf?src-supplier=Digi-Key; ComponentLink9Description: https://www.seielect.com/catalog/SEI-Packaging.pdf?src-supplier=Digi-Key; ComponentLink9URL: https://www.seielect.com/catalog/SEI-Packaging.pdf?src-supplier=Digi-Key; Features: Moisture Resistant,Anti-Sulfur; Height: 0.024inch; Lead Free: Lead Free; Length: 0.079inch; Manufacturer Part Number 1: RNCP0805FTD1K00; Max Operating Temperature: 155°C; Min Operating Temperature: -55°C; Mount: Surface Mount; Number of Terminations: 2; Packaging: Tape and Reel; Power Rating: 250mW; Pricing 1: 1=0,10000, 10=0,07300, 100=0,02970, 1000=0,01336, 2500=0,01159 (USD); Resistor Type: Thin Film; RoHS: Yes; RoHS Compliant: Yes; Series: RNCP; Size Code: 0805; Stock 1: 333101; Supplier 1: Digi-Key; Supplier Part Number 1: RNCP0805FTD1K00CT-ND; Tolerance: 1%; Width: 0.049inch; .SCHChecked: Victor Holanda; Library Name: Softex.SchLib
R4 R19 R23 = ESR10EZPJ472: RES - 4K7 0.4W 0805 ±5%; Manufacturer 1: Rohm; .PCBChecked: Victor Holanda; Max Power Dissipation: 400mW; Resistance: 4.7kR; Temperature Coefficient: 200ppm/°C; Case Code (Imperial): 0805; Case Code (Metric): 2012; Case/Package: 0805; Category: Chip SMD Resistors; Component Kind: Standard; ComponentLink7Description: https://datasheet.ciiva.com/16212/esr10ezpd-16212069.pdf?src-supplier=Future+Electronics; ComponentLink7URL: https://datasheet.ciiva.com/16212/esr10ezpd-16212069.pdf?src-supplier=Future+Electronics; Features: Automotive AEC-Q200; Lead Free: Lead Free; Manufacturer Part Number 1: ESR10EZPJ472; Number of Terminations: 2; Packaging: Tape and Reel; Power Rating: 400mW; Pricing 1: 1=0,10000, 10=0,09000, 100=0,03450, 1000=0,01518, 2500=0,01311 (USD); RoHS: Yes; RoHS Compliant: Yes; Series: ESR; Size Code: 0805; Stock 1: 374197; Supplier 1: Digi-Key; Supplier Part Number 1: RHM4.7KKCT-ND; Tolerance: 5%; .SCHChecked: Victor Holanda; Library Name: Softex.SchLib
R6 = ERJ-6DQJ3R0V: RES - 3Ω 1/2W 0805 ±5%; Manufacturer 1: Panasonic; .PCBChecked: Victor H; Max Power Dissipation: 500mW; Resistance: 3R; Temperature Coefficient: 100ppm/°C; Case Code (Imperial): 0805; Case Code (Metric): 2012; Case/Package: 0805; Category: Chip SMD Resistors; Component Kind: Standard; ComponentLink1Description: https://industrial.panasonic.com/cdbs/www-data/pdf/RDN0000/AOA0000C313.pdf?src-supplier=Digi-Key; ComponentLink1URL: https://industrial.panasonic.com/cdbs/www-data/pdf/RDN0000/AOA0000C313.pdf?src-supplier=Digi-Key; Features: Automotive AEC-Q200; Height: 0.028inch; Length: 0.079inch; Manufacturer Part Number 1: ERJ-6DQJ3R0V; Max Operating Temperature: 155°C; Min Operating Temperature: -55°C; Number of Terminations: 2; Packaging: Tape and Reel; Pricing 1: 1=0,24000, 10=0,20600, 25=0,15000, 50=0,10880, 100=0,08070, 250=0,06192, 500=0,04878, 1000=0,03377 (USD); Resistor Type: Thick Film; RoHS: Yes; Stock 1: 5000; Supplier 1: Digi-Key; Supplier Part Number 1: P19231CT-ND; Tolerance: 5%; Width: 0.049inch; .SCHChecked: Victor H; Library Name: softex-devkit-visao.SchLib
R7 R20 R25 R27 R31 R33 = RNCP0805FTD10K0: RES - 10K 1/4W 0805 ±1%; Manufacturer 1: Stackpole Electronics; .PCBChecked: Victor Holanda; Max Power Dissipation: 250mW; Resistance: 10kR; Temperature Coefficient: 100ppm/°C; Case Code (Imperial): 0805; Case Code (Metric): 2012; Case/Package: 0805; Category: Chip SMD Resistors; Component Kind: Standard; ComponentLink7Description: https://www.seielect.com/catalog/SEI-Packaging.pdf?src-supplier=Digi-Key; ComponentLink7URL: https://www.seielect.com/catalog/SEI-Packaging.pdf?src-supplier=Digi-Key; Features: Moisture Resistant,Anti-Sulfur; Height: 0.024inch; Lead Free: Lead Free; Length: 0.079inch; Manufacturer Part Number 1: RNCP0805FTD10K0; Max Operating Temperature: 155°C; Min Operating Temperature: -55°C; Mount: Surface Mount; Number of Terminations: 2; Packaging: Tape and Reel; Pricing 1: 1=0,10000, 10=0,07300, 100=0,02970, 1000=0,01336, 2500=0,01159 (USD); Resistor Type: Thin Film; RoHS: Yes; RoHS Compliant: Yes; Series: RNCP; Stock 1: 744134; Supplier 1: Digi-Key; Supplier Part Number 1: RNCP0805FTD10K0CT-ND; Tolerance: 1%; Width: 0.049inch; .SCHChecked: Victor Holanda; Library Name: Softex.SchLib
R9 R32 = RMCF0805FT30K0: RES - 30K 1/8W 0805 ±1%; Manufacturer: Stackpole Electronics; .PCBChecked: Victor H; Max Power Dissipation: 125mW; Resistance: 30kR; Temperature Coefficient: 100ppm/°C; Case/Package: 0805; Category: Chip SMD Resistors; Component Kind: Standard; ComponentLink1Description: http://components.arrow.com/part/dataview/40964155S7714593N1174?src-supplier=Arrow+Electronics; ComponentLink1URL: http://components.arrow.com/part/dataview/40964155S7714593N1174?src-supplier=Arrow+Electronics; ComponentLink2Description: https://www.seielect.com/catalog/sei-rmcf_rmcp.pdf?src-supplier=Digi-Key; ComponentLink2URL: https://www.seielect.com/catalog/sei-rmcf_rmcp.pdf?src-supplier=Digi-Key; ComponentLink3Description: https://www.seielect.com/catalog/SEI-Packaging.pdf?src-supplier=Digi-Key; ComponentLink3URL: https://www.seielect.com/catalog/SEI-Packaging.pdf?src-supplier=Digi-Key; ComponentLink4Description: https://www.seielect.com/catalog/SEI-RMCF_RMCP.pdf?src-supplier=Avnet; ComponentLink4URL: https://www.seielect.com/catalog/SEI-RMCF_RMCP.pdf?src-supplier=Avnet; Contact Plating: Tin,Matte; Features: Automotive AEC-Q200; Height: 0.026inch; Lead Free: Lead Free; Length: 0.079inch; Manufacturer Part Number: RMCF0805FT30K0; Max Operating Temperature: 155°C; Min Operating Temperature: -55°C; Mount: Surface Mount; mounting: SMD; Number of Terminations: 2; Package Quantity: 5000; Packaging: Tape and Reel; Pricing 1: 1=0,10000, 10=0,02700, 100=0,01090, 1000=0,00488, 2500=0,00423 (USD); Radiation Hardening: No; Resistor Type: Thick Film; RoHS: Yes; RoHS Compliant: Yes; Series: RMCF; Stock 1: 854420; Supplier 1: Digi-Key; Supplier Part Number 1: RMCF0805FT30K0CT-ND; Tolerance: 1%; Width: 0.049inch; .SCHChecked: Victor H; Library Name: softex-devkit-visao.SchLib
R18 R22 R26 = RNCP0805FTD47K5: RES - 47K5 1/4W 0805 ±1%; Manufacturer 1: Stackpole Electronics; .PCBChecked: Victor H; Max Power Dissipation: 250mW; Resistance: 47.5kR; Temperature Coefficient: 100ppm/°C; Case Code (Imperial): 0805; Case Code (Metric): 2012; Case/Package: 0805; Category: Chip SMD Resistors; Component Kind: Standard; ComponentLink7Description: http://www.seielect.com/Catalog/SEI-rncp.pdf?src-supplier=Digi-Key; ComponentLink7URL: http://www.seielect.com/Catalog/SEI-rncp.pdf?src-supplier=Digi-Key; ComponentLink8Description: https://www.seielect.com/catalog/SEI-Packaging.pdf?src-supplier=Digi-Key; ComponentLink8URL: https://www.seielect.com/catalog/SEI-Packaging.pdf?src-supplier=Digi-Key; Features: Moisture Resistant,Anti-Sulfur; Height: 0.024inch; Length: 0.079inch; Manufacturer Part Number 1: RNCP0805FTD47K5; Max Operating Temperature: 155°C; Min Operating Temperature: -55°C; Mount: Surface Mount; Number of Terminations: 2; Packaging: Tape and Reel; Pricing 1: 1=0,10000, 10=0,07300, 100=0,02970, 1000=0,01336, 2500=0,01159 (USD); Resistor Type: Thin Film; RoHS: Yes; RoHS Compliant: Yes; Series: RNCP; Stock 1: 36830; Supplier 1: Digi-Key; Supplier Part Number 1: RNCP0805FTD47K5CT-ND; Tolerance: 1%; Width: 0.049inch; .SCHChecked: Victor H
  R18 R22: Library Name: softex-devkit-visao.SchLib
  R26: Library Name: Softex.SchLib
R21 = RMCF0805FT120K: RES - 120K 1/8W 0805 ±1%; Manufacturer: Stackpole Electronics; Max Power Dissipation: 125mW; Resistance: 120kR; Temperature Coefficient: 100ppm/°C; Case Code (Imperial): 0805; Case Code (Metric): 2012; Case/Package: 0805; Category: Chip SMD Resistors; Component Kind: Standard; ComponentLink1Description: https://www.seielect.com/catalog/SEI-Packaging.pdf?src-supplier=Digi-Key; ComponentLink1URL: https://www.seielect.com/catalog/SEI-Packaging.pdf?src-supplier=Digi-Key; ComponentLink2Description: https://www.seielect.com/Catalog/SEI-RMCF_RMCP.pdf?src-supplier=Digi-Key; ComponentLink2URL: https://www.seielect.com/Catalog/SEI-RMCF_RMCP.pdf?src-supplier=Digi-Key; ComponentLink3Description: https://www.seielect.com/catalog/SEI-RMCF_RMCP.pdf?src-supplier=Avnet; ComponentLink3URL: https://www.seielect.com/catalog/SEI-RMCF_RMCP.pdf?src-supplier=Avnet; Contact Plating: Tin,Matte; Features: Automotive AEC-Q200; Height: 0.026inch; Lead Free: Lead Free; Length: 0.079inch; Manufacturer Part Number: RMCF0805FT120K; Max Operating Temperature: 155°C; Min Operating Temperature: -55°C; Mount: Surface Mount; Number of Terminations: 2; Packaging: Tape and Reel; Pricing 1: 1=0,10000, 10=0,02700, 100=0,01090, 1000=0,00488, 2500=0,00423 (USD); Radiation Hardening: No; Resistor Type: Thick Film; RoHS: Yes; RoHS Compliant: Yes; Series: RMCF; Stock 1: 24760; Supplier 1: Digi-Key; Supplier Part Number 1: RMCF0805FT120KCT-ND; Tolerance: 1%; Width: 0.049inch; Library Name: softex-devkit-visao.SchLib
R24 = RMCF0805FT22K1: RES - 22K1 1/8W 0805 ±1%; Manufacturer 1: Stackpole Electronics; .PCBChecked: Victor H; Max Power Dissipation: 125mW; Resistance: 22.1kR; Temperature Coefficient: 100ppm/°C; Case Code (Imperial): 0805; Case Code (Metric): 2012; Case/Package: 0805; Category: Chip SMD Resistors; Component Kind: Standard; ComponentLink7Description: https://datasheet.ciiva.com/15193/getdatasheetpartid-409700-15193558.pdf?src-supplier=Verical; ComponentLink7URL: https://datasheet.ciiva.com/15193/getdatasheetpartid-409700-15193558.pdf?src-supplier=Verical; Construction: Rectangular; Contact Plating: Tin,Matte; Depth: 1.25mm; Features: Automotive AEC-Q200; Height: 0.026inch; Lead Free: Lead Free; Length: 0.079inch; Manufacturer Part Number 1: RMCF0805FT22K1; Max Operating Temperature: 155°C; Military Standard: Not; Min Operating Temperature: -55°C; Mount: Surface Mount; Number of Terminations: 2; Packaging: Tape and Reel; Power Rating: 125mW; Pricing 1: 1=0,10000, 10=0,02700, 100=0,01090, 1000=0,00488, 2500=0,00423 (USD); Radiation Hardening: No; Resistor Type: Thick Film; RoHS: Yes; RoHS Compliant: Yes; Series: RMCF; Size Code: 0805; Stock 1: 1311048; Supplier 1: Digi-Key; Supplier Part Number 1: RMCF0805FT22K1CT-ND; Tolerance: 1%; Width: 0.049inch; .SCHChecked: Victor H; Library Name: Softex.SchLib
R29 = RMCF0805ZT0R00: RES - 0R 1/8W 0805; Manufacturer 1: Stackpole Electronics; .PCBChecked: Victor Holanda; Max Power Dissipation: 125mW; mouting: SMD; Case Code (Imperial): 0805; Case Code (Metric): 2012; Case/Package: 0805; Category: Chip SMD Resistors; Component Kind: Standard; ComponentLink7Description: https://datasheet.ciiva.com/15193/getdatasheetpartid-360417-15193658.pdf?src-supplier=Verical; ComponentLink7URL: https://datasheet.ciiva.com/15193/getdatasheetpartid-360417-15193658.pdf?src-supplier=Verical; Construction: Rectangular; Contact Plating: Tin,Matte; Depth: 1.25mm; Features: Automotive AEC-Q200; Height: 0.026inch; Lead Free: Lead Free; Length: 2mm; Manufacturer Part Number 1: RMCF0805ZT0R00; Max Operating Temperature: 155°C; Military Standard: Not; Min Operating Temperature: -55°C; Mount: Surface Mount; Number of Terminations: 2; Packaging: Tape and Reel; Power Rating: 125mW; Pricing 1: 1=0,10000, 10=0,01700, 25=0,01280, 50=0,00960, 100=0,00710, 250=0,00540, 500=0,00432, 1000=0,00318 (USD); Radiation Hardening: No; Resistance: 0R; Resistor Type: Thick Film; RoHS: Yes; RoHS Compliant: Yes; Series: RMCF; Size Code: 0805; Stock 1: 6449300; Supplier 1: Digi-Key; Supplier Part Number 1: RMCF0805ZT0R00CT-ND; Width: 0.049inch; .SCHChecked: Victor Holanda; Library Name: softex-devkit-visao.SchLib
TP11-TP25 = Test_Point: MECH - Test Points; Library Name: softex-devkit-visao.SchLib
U1 = BD70HC5WEFJ-E2: IC - LDO Voltage Controllers LDO Reg Pos 7.0V 1.5A; Manufacturer 1: Rohm; .PCBChecked: Victor H; Dropout Voltage: 600mV; Max Input Voltage: 8V; Max Power Dissipation: 2.11W; Min Input Voltage: 4.5V; Number of Outputs: 1; Output Type: Fixed; Output Voltage: 7V; Category: Voltage Regulators - Linear; Component Kind: Standard; ComponentLink1Description: https://datasheet.ciiva.com/12217/bd70hc5wefj-214377-12217508.pdf?src-supplier=Mouser; ComponentLink1URL: https://datasheet.ciiva.com/12217/bd70hc5wefj-214377-12217508.pdf?src-supplier=Mouser; ComponentLink2Description: https://datasheet.ciiva.com/6584/bdxxhc5wefj-e-6584252.pdf?src-supplier=Digi-Key; ComponentLink2URL: https://datasheet.ciiva.com/6584/bdxxhc5wefj-e-6584252.pdf?src-supplier=Digi-Key; ComponentLink3Description: https://datasheet.ciiva.com/6584/bdxxhc5wefj-e-6584253.pdf?src-supplier=Digi-Key; ComponentLink3URL: https://datasheet.ciiva.com/6584/bdxxhc5wefj-e-6584253.pdf?src-supplier=Digi-Key; ComponentLink4Description: https://datasheet.ciiva.com/6584/bdxxhc5wefj-e-6584254.pdf?src-supplier=Digi-Key; ComponentLink4URL: https://datasheet.ciiva.com/6584/bdxxhc5wefj-e-6584254.pdf?src-supplier=Digi-Key; Lead Free: Lead Free; Manufacturer Part Number 1: BD70HC5WEFJ-E2; Max Operating Temperature: 85°C; Min Operating Temperature: -25°C; Mount: Surface Mount; Number of Pins: 8; Number of Regulators: 1; Output Current: 1.5A; Package Quantity: 1; Packaging: Tape and Reel; Pricing 1: 1=0,75000, 10=0,65800, 25=0,61760, 100=0,50420, 250=0,46832, 500=0,39856, 1000=0,31885 (USD); RoHS: Yes; RoHS Compliant: Yes; Series: -; Stock 1: 4936; Supplier 1: Digi-Key; Supplier Part Number 1: BD70HC5WEFJ-E2CT-ND; .SCHChecked: Victor H; Library Name: softex-devkit-visao.SchLib
U7 = CP2102N-A02-GQFN24R: IC - Full Speed USB to UART Bridge USB 2.0 3.3V T/R Automotive 24-Pin QFN EP; Manufacturer 1: Silicon Labs; .PCBChecked: Victor H; Category: Interface ICs; Component Kind: Standard; Manufacturer Part Number 1: CP2102N-A02-GQFN24R; Pricing 1: 1=2,16000 (USD); RoHS: Yes; Stock 1: 31086; Supplier 1: Digi-Key; Supplier 2: Mouser; Supplier Part Number 1: 336-5888-1-ND; Supplier Part Number 2: 634-CP2102NA02QFN24R; .SCHChecked: Victor H; Library Name: softex-devkit-visao.SchLib
U8 = ESP32-S3: IC - SMD ESP32-S3, Dual-core Mcu,; Manufacturer: Espressif Systems; .PCBChecked: Victor H; Component Kind: Standard; Manufacturer Part Number: ESP32-S3; mounting: SMD; Pricing 1: 1=2,04000 (USD); RoHS: No; Stock 1: 2000; Supplier 1: Digi-Key; Supplier Part Number 1: 1965-ESP32-S3-ND; .SCHChecked: Victor H; Library Name: softex-devkit-visao.SchLib
U9 U10 = AP7366-W5-7: IC - LDO Regulator Positive Adj 0.8V to 5V 600mA 5-Pin SOT-25 T/R; Manufacturer: Diodes; Component Kind: Standard; ComponentLink1Description: https://www.diodes.com/assets/Datasheets/AP7366.pdf?src-supplier=Digi-Key; ComponentLink1URL: https://www.diodes.com/assets/Datasheets/AP7366.pdf?src-supplier=Digi-Key; Manufacturer Part Number: AP7366-W5-7; Pricing 1: 1=0,42000, 10=0,31700, 25=0,28640, 100=0,19780, 250=0,16656, 500=0,13532, 1000=0,10409 (USD); RoHS: Yes; Stock 1: 371289; Supplier 1: Digi-Key; Supplier Part Number 1: AP7366-W5-7DICT-ND; Library Name: softex-devkit-visao.SchLib
* = CAM_OV2640: Library Name: softex-devkit-visao.SchLib
